# Altium schematic sheet FPGA_POWER.SchDoc(FPGA_POWER)
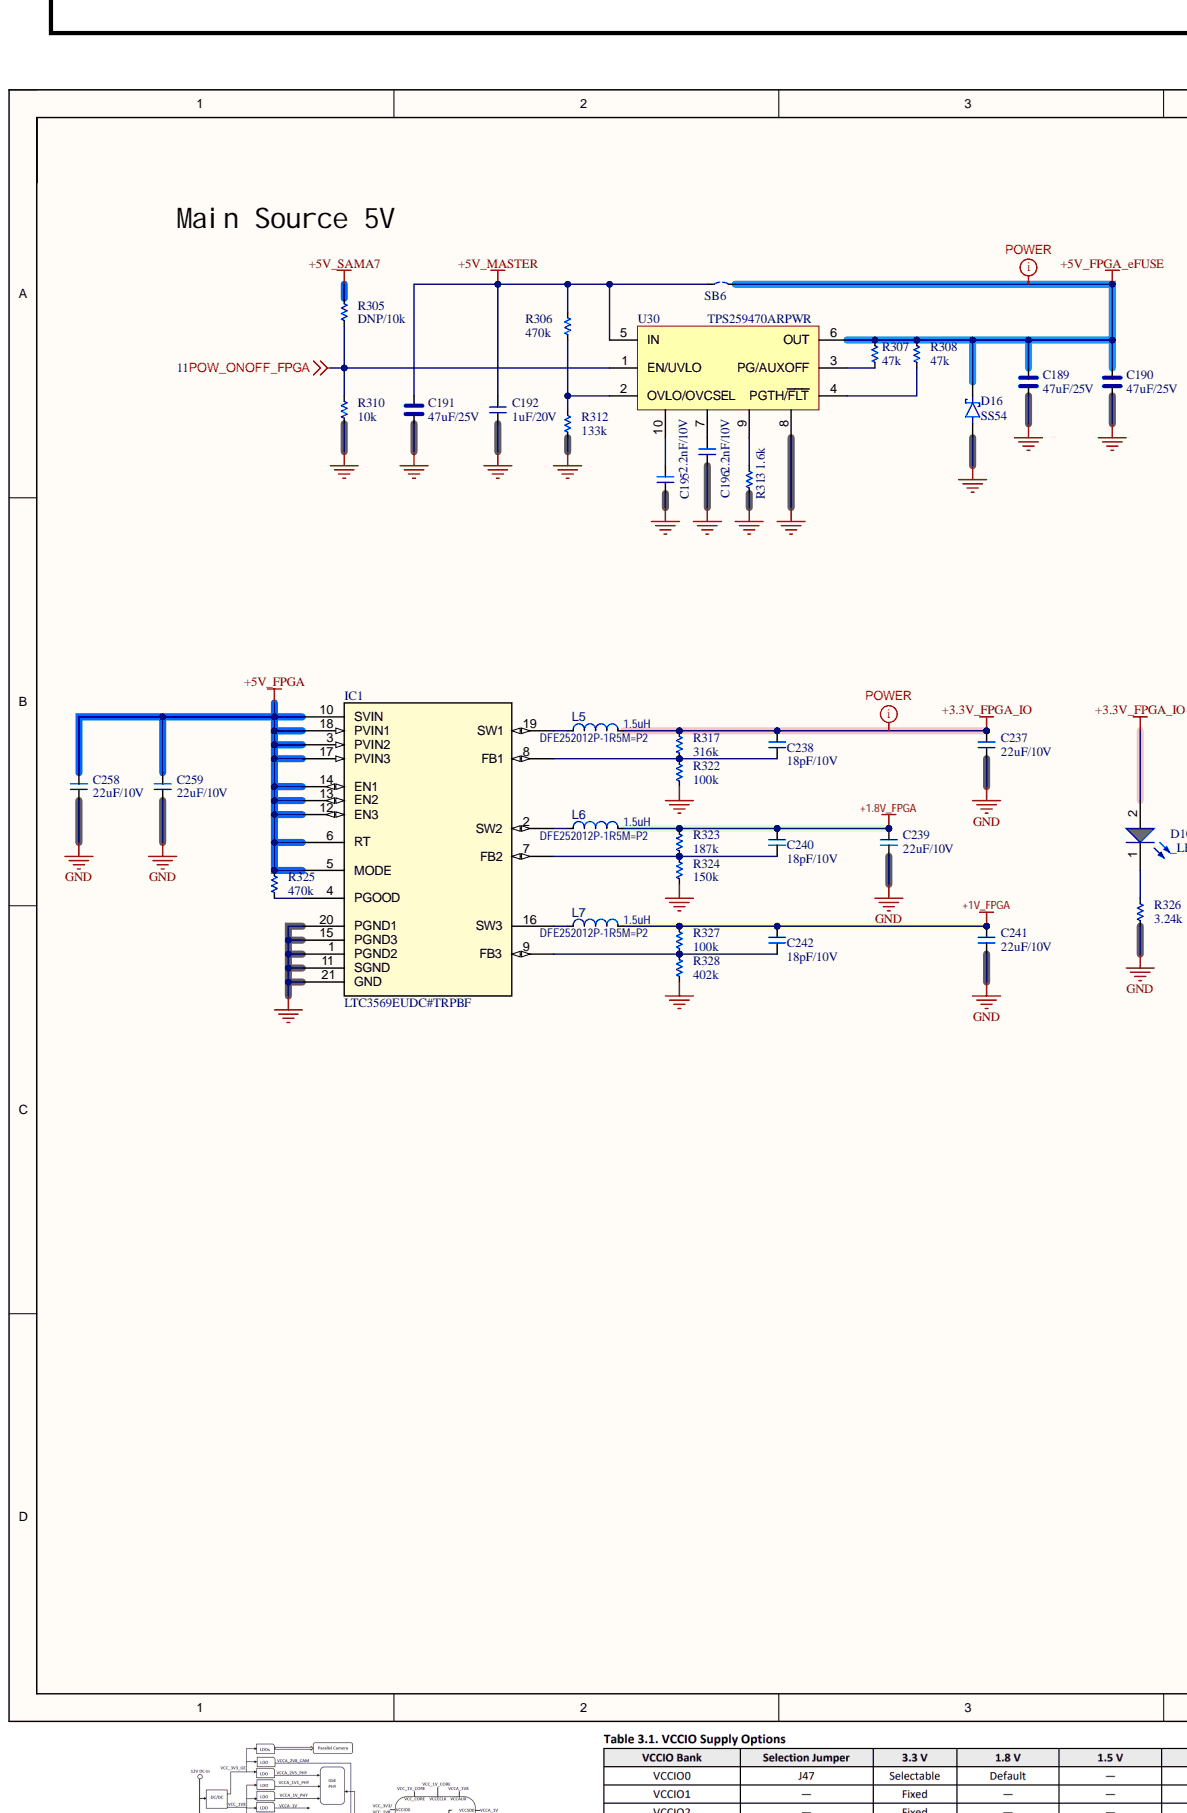
[diagram p0: FPGA_POWER.SchDoc, left]
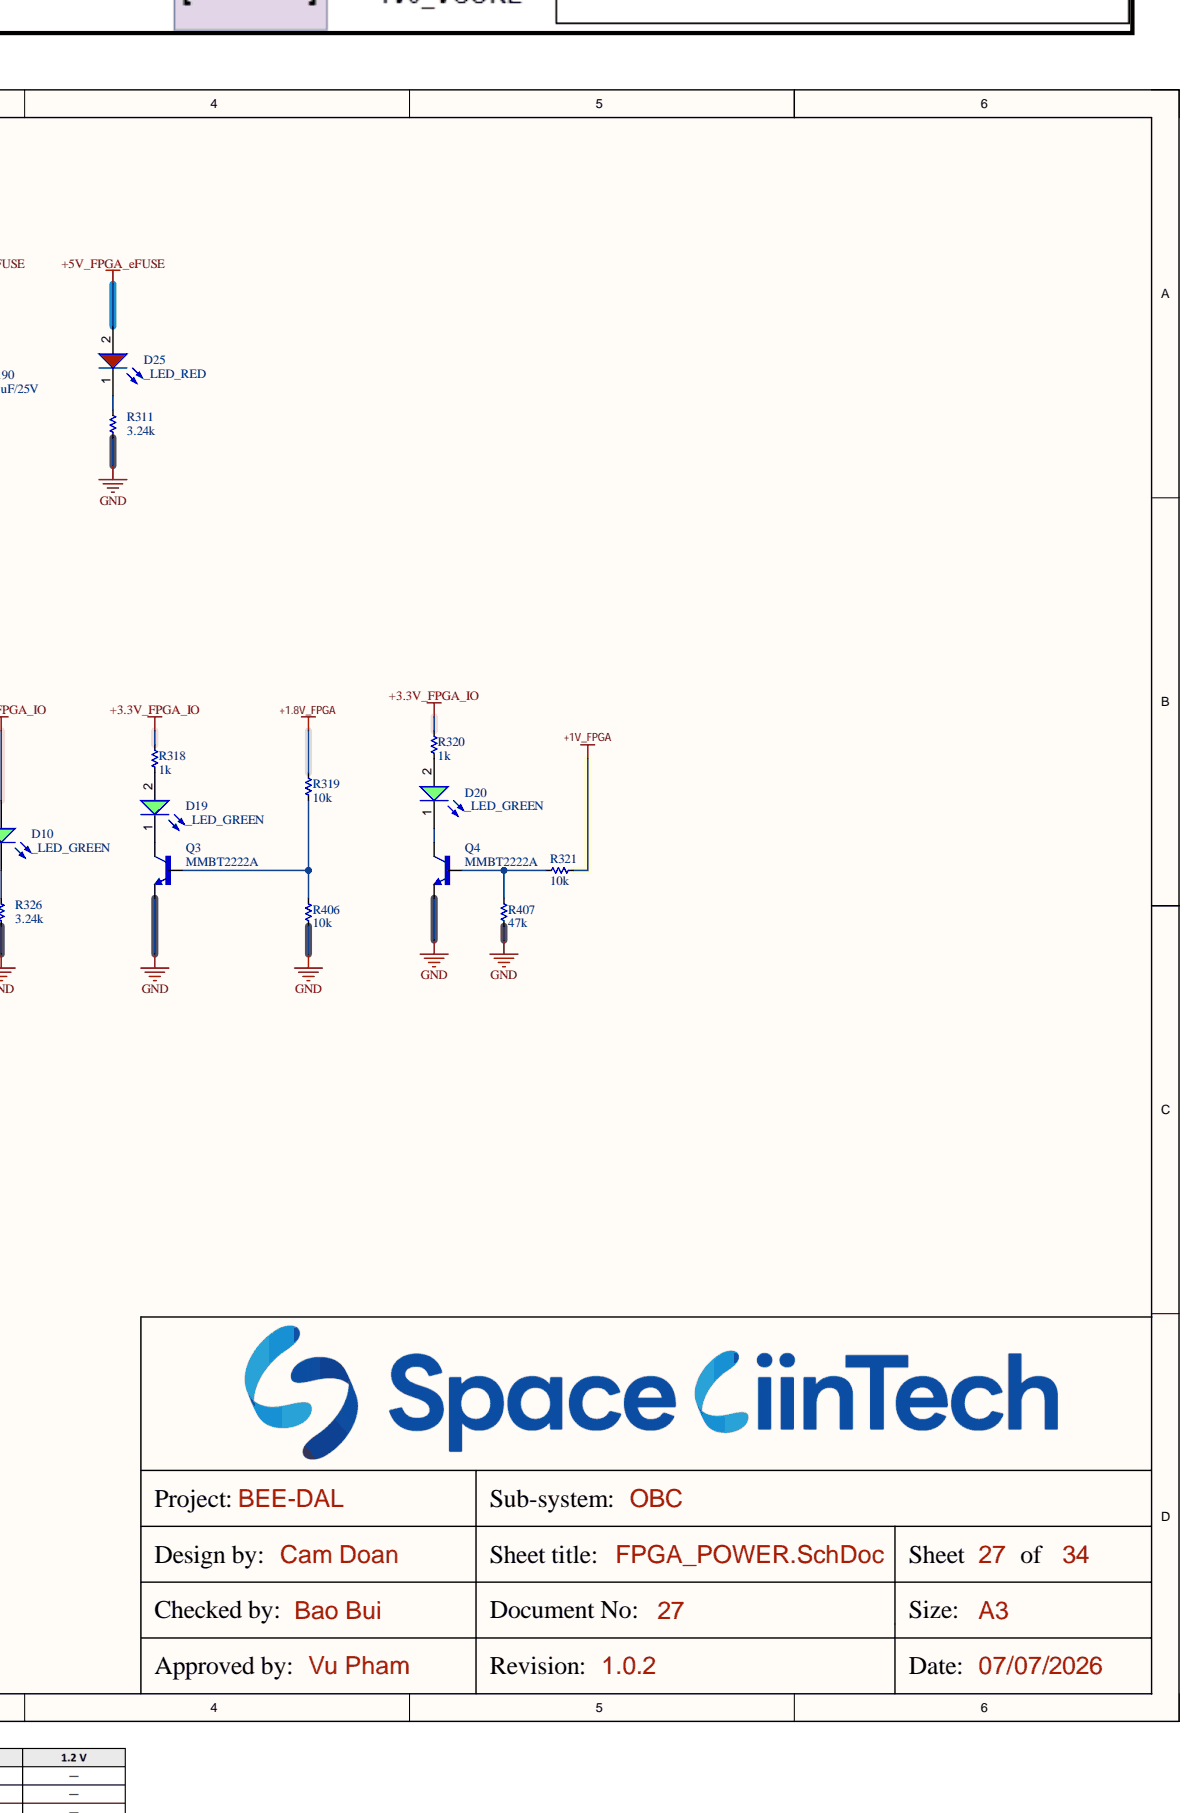
[diagram p1: FPGA_POWER.SchDoc, right]

## Parts
C189  47uF/25V  [CAP_C_3225X80]
C190  47uF/25V  [CAP_C_3225X80]
C191  47uF/25V  [CAP_C_3225X80]
C192  1uF/20V  [CAP_C_1005X50_L]
C195  2.2nF/10V  [CAP_C_1005X50_L]
C196  2.2nF/10V  [CAP_C_1005X50_L]
C237  22uF/10V  [CAP_C_1608X80]
C238  18pF/10V  [CAP_C_1005X50_L]
C239  22uF/10V  [CAP_C_1608X80]
C240  18pF/10V  [CAP_C_1005X50_L]
C241  22uF/10V  [CAP_C_1608X80]
C242  18pF/10V  [CAP_C_1005X50_L]
C258  22uF/10V  [CAP_C_1608X80]
C259  22uF/10V  [CAP_C_1608X80]
D10  _LED_GREEN  [LED_0603]
D16  SS54  [DO-214AC_SMA]
D19  _LED_GREEN  [LED_0603]
D20  _LED_GREEN  [LED_0603]
D25  _LED_RED  [LED_0603]
IC1  LTC3569EUDC#TRPBF  [QFN20_UDC_3X4_ADI]
L5  DFE252012P-1R5M=P2  value 1.5uH  [IND_C_1008]
L6  DFE252012P-1R5M=P2  value 1.5uH  [IND_C_1008]
L7  DFE252012P-1R5M=P2  value 1.5uH  [IND_C_1008]
Q3  MMBT2222A  [SOT95P240X120-3N]
Q4  MMBT2222A  [SOT95P240X120-3N]
R305  DNP/10k  [RES_C_1005x30_L]
R306  470k  [RES_C_1005x30_L]
R307  47k  [RES_C_1005x30_L]
R308  47k  [RES_C_1005x30_L]
R310  10k  [RES_C_1005x30_L]
R311  3.24k  [RES_C_1005x30_L]
R312  133k  [RES_C_1005x30_L]
R313  1.6k  [RES_C_1005x30_L]
R317  316k  [RES_C_1005x30_L]
R318  1k  [RES_C_1005x30_L]
R319  10k  [RES_C_1005x30_L]
R320  1k  [RES_C_1005x30_L]
R321  10k  [RES_C_1005x30_L]
R322  100k  [RES_C_1005x30_L]
R323  187k  [RES_C_1005x30_L]
R324  150k  [RES_C_1005x30_L]
R325  470k  [RES_C_1005x30_L]
R326  3.24k  [RES_C_1005x30_L]
R327  100k  [RES_C_1005x30_L]
R328  402k  [RES_C_1005x30_L]
R406  10k  [RES_C_1005x30_L]
R407  47k  [RES_C_1005x30_L]
SB6  JUMPER  [JUMPER_1608]  (value not drawn)
U30  TPS259470ARPWR  [VQFN-HR-10]

## Nets
+1.8V_FPGA: C239.1 C240.1 L6.2 R319.1 R323.2
+1V_FPGA: C241.1 C242.1 L7.2 R321.1 R327.2
+3.3V_FPGA_IO: C237.1 C238.1 D10.2 L5.2 R317.2 R318.2 R320.2
+5V_FPGA: C258.1 C259.1 IC1.3(PVIN2) IC1.5(MODE) IC1.6(RT) IC1.10(SVIN) IC1.12(EN3) IC1.13(EN2) IC1.14(EN1) IC1.17(PVIN3) IC1.18(PVIN1) R325.2
+5V_FPGA_eFUSE: C189.2 C190.2 D16.1 D25.2 R307.2 R308.2 SB6.2 U30.6(OUT)
+5V_MASTER: C191.2 C192.2 R306.2 SB6.1 U30.5(IN)
+5V_SAMA7: R305.1
GND: C189.1 C190.1 C191.1 C192.1 C195.1 C196.1 C237.2 C239.2 C241.2 C258.2 C259.2 D16.2 IC1.1(PGND2) IC1.11(SGND) IC1.15(PGND3) IC1.20(PGND1) IC1.21(GND) Q3.2 Q4.2 R310.2 R311.1 R312.1 R313.1 R322.1 R324.1 R326.1 R328.1 R406.2 R407.1 U30.8
POW_ONOFF_FPGA: R305.2 R310.1 U30.1(EN/UVLO)
NetC195_2: C195.2 U30.10
NetC196_2: C196.2 U30.7
NetC238_2: C238.2 IC1.8(FB1) R317.1 R322.2
NetC240_2: C240.2 IC1.7(FB2) R323.1 R324.2
NetC242_2: C242.2 IC1.9(FB3) R327.1 R328.2
NetD10_1: D10.1 R326.2
NetD19_1: D19.1 Q3.3
NetD19_2: D19.2 R318.1
NetD20_1: D20.1 Q4.3
NetD20_2: D20.2 R320.1
NetD25_1: D25.1 R311.2
NetIC1_2: IC1.2(SW2) L6.1
NetIC1_4: IC1.4(PGOOD) R325.1
NetIC1_16: IC1.16(SW3) L7.1
NetIC1_19: IC1.19(SW1) L5.1
NetQ3_1: Q3.1 R319.2 R406.1
NetQ4_1: Q4.1 R321.2 R407.2
NetR306_1: R306.1 R312.2 U30.2(OVLO/OVCSEL)
NetR307_1: R307.1 U30.3(PG/AUXOFF)
NetR308_1: R308.1 U30.4(PGTH/FLT)
NetR313_2: R313.2 U30.9

## Library data of the parts
C189-C191 = _CAP_C_High_2: CAP CHIP High Value; Component Kind: Standard; Library Name: IFBschelib.SchLib
C192 C195 C196 = _CAP_C_2: CAP CHIP; Component Kind: Standard; Library Name: IFBschelib.SchLib
C237-C242 C258 C259 = _CAP_C_2: CAP; Component Kind: Standard; Library Name: LABLIB.SchLib
D10 D19 D20 = LED_GREEN: LED GREEN CLEAR 0603 SMD; Component Kind: Standard; Library Name: IFBschelib.SchLib
D16 = DIODE SCHOTTKY: Component Kind: Standard; Description: DIODE SCHOTTKY; Library Name: LABLIB.SchLib
D25 = LED_RED: LED RED CLEAR 0603 SMD; Component Kind: Standard; Library Name: IFBschelib.SchLib
IC1 = LTC3569EUDCTRPBF: Triple Buck Regulator with 1.2A and Two 600mA Outputs and Individual Programmable References; Manufacturer_Name: Analog Devices Inc; Component Kind: Standard; Manufacturer_Part_Number: LTC3569EUDC#TRPBF; RefDes: RefDes; Type: Type; Copyright: Copyright (C) 2025 Ultra Librarian. All rights reserved.; Library Name: LABLIB.SchLib
L5-L7 = IND1335: Inductor; Manufacturer 1: Murata Electronics North America; DCR: 0.06R; Description_: INDUCTOR 1.5uH 3.5A 20% SMD 1008; Dev Tools Status: Design; Owner: Razvan Cristescu; Populated: YES; RMS Current: 3.5A; Test Frequency: 1MHz; Category: Inductor; Component Kind: Standard; ComponentLink1Description: SVN Datasheet; ComponentLink1URL: https://chn-vm-apps.microchip.com/mchprepo/parts/Datasheets/m_dfe252012p.pdf; ComponentLink2Description: Local Datasheet; ComponentLink3Description: Open Symbol; ComponentLink4Description: Open Footprint; ComponentLink5Description: Open Footprint 2; ComponentLink6Description: Open Footprint 3; ComponentLink7Description: Open Footprint 4; Manufacturer Part Number 1: DFE252012P-1R5M=P2; MCHP-ID: IND1335; Package: 1008; Quantity Override: 1; Revision: A; Status: MCL Design; Supplier 1: Digi-Key; Supplier Part Number 1: 490-10648-6-ND; Symbol: Inductor; Type: Inductor; ComponentLink2URL: C:\ALTIUM_WORK\00001_MCHP-CDB\Datasheets\m_dfe252012p.pdf; ComponentLink3URL: C:\ALTIUM_WORK\00001_MCHP-CDB\Library\LibraryRefs\Inductor.SchLib; ComponentLink4URL: C:\ALTIUM_WORK\00001_MCHP-CDB\Library\Footprints\IND_C_1008.PcbLib; Library Name: LABLIB.SchLib
Q3 Q4 = BJT_NPN_BEC: MF: Diotec Semiconductor; Check_prices: https://www.snapeda.com/parts/MMBT2222A/Diotec/view-part/?ref=eda; Component Kind: Standard; MP: MMBT2222A; Package: SOT-23 Diotec; SnapEDA_Link: https://www.snapeda.com/parts/MMBT2222A/Diotec/view-part/?ref=snap; Library Name: LABLIB.SchLib
R305-R308 R310-R313 R317-R328 R406 R407 = _RES_B_2: RES; Component Kind: Standard
  R305 R306 R310 R312 R317-R325 R327 R328 R406: Library Name: LABLIB.SchLib
  R307 R308 R311 R313 R326 R407: Library Name: IFBschelib.SchLib
SB6 = JUMPER: Component Kind: Standard; Library Name: IFBschelib.SchLib
U30 = TPS259470ARPWR: Component Kind: Standard; Library Name: IFBschelib.SchLib
